# Altium schematic sheet pixracer_serials.SchDoc(pixracer_serials)
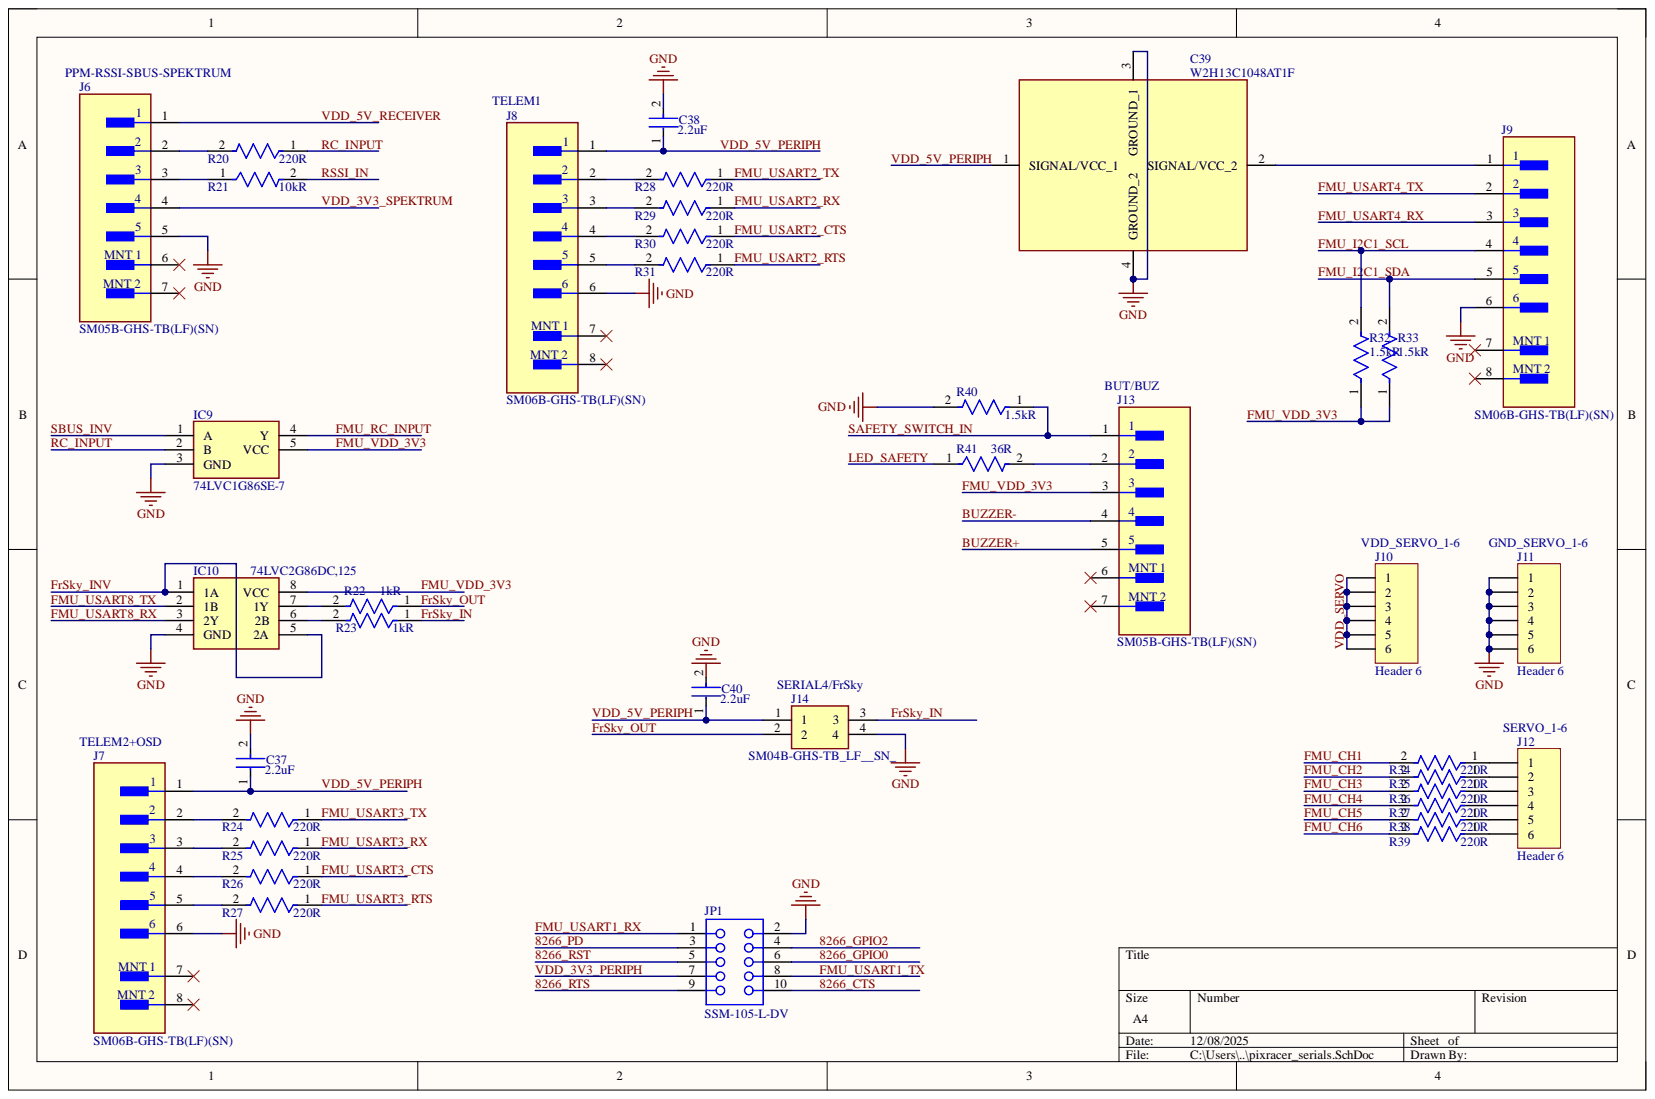
[diagram p0: pixracer_serials.SchDoc]

## Parts
C37  Capacitance: 2.2uF  [FP-C1608-080-0_1-MFG]  (hidden comment: C1608X7R1A225K080AC)
C38  Capacitance: 2.2uF  [FP-C1608-080-0_1-MFG]  (hidden comment: C1608X7R1A225K080AC)
C39  W2H13C1048AT1F  [W2H13C1048AT1A]
C40  Capacitance: 2.2uF  [FP-C1608-080-0_1-MFG]  (hidden comment: C1608X7R1A225K080AC)
IC9  74LVC1G86SE-7  [SOT65P210X110-5N]
IC10  74LVC2G86DC,125  [SOP50P310X100-8N]
J6  SM05B-GHS-TB(LF)(SN)  [FP-SM05B-GHS-TB_LF_SN-MFG]
J7  SM06B-GHS-TB(LF)(SN)  [FP-SM06B-GHS-TB_LF_SN-MFG]
J8  SM06B-GHS-TB(LF)(SN)  [FP-SM06B-GHS-TB_LF_SN-MFG]
J9  SM06B-GHS-TB(LF)(SN)  [FP-SM06B-GHS-TB_LF_SN-MFG]
J10  Header 6  [HDR1X6]
J11  Header 6  [HDR1X6]
J12  Header 6  [HDR1X6]
J13  SM05B-GHS-TB(LF)(SN)  [FP-SM05B-GHS-TB_LF_SN-MFG]
J14  SM04B-GHS-TB_LF__SN_  [SM04B-GHS-TB]
JP1  SSM-105-L-DV  [SMTC-SSM-105-X-DV]
R20  Resistance: 220R  [FP-CRCW0603-e3-MFG]  (hidden comment: CRCW0603220RFKEB)
R21  Resistance: 10kR  [FP-ERJ3G-IPC_C]  (hidden comment: ERJ-3GEYJ103V)
R22  Resistance: 1kR  [FP-RC1608-IPC_A]  (hidden comment: RC1608J102CS)
R23  Resistance: 1kR  [FP-RC1608-IPC_A]  (hidden comment: RC1608J102CS)
R24  Resistance: 220R  [FP-CRCW0603-e3-MFG]  (hidden comment: CRCW0603220RFKEB)
R25  Resistance: 220R  [FP-CRCW0603-e3-MFG]  (hidden comment: CRCW0603220RFKEB)
R26  Resistance: 220R  [FP-CRCW0603-e3-MFG]  (hidden comment: CRCW0603220RFKEB)
R27  Resistance: 220R  [FP-CRCW0603-e3-MFG]  (hidden comment: CRCW0603220RFKEB)
R28  Resistance: 220R  [FP-CRCW0603-e3-MFG]  (hidden comment: CRCW0603220RFKEB)
R29  Resistance: 220R  [FP-CRCW0603-e3-MFG]  (hidden comment: CRCW0603220RFKEB)
R30  Resistance: 220R  [FP-CRCW0603-e3-MFG]  (hidden comment: CRCW0603220RFKEB)
R31  Resistance: 220R  [FP-CRCW0603-e3-MFG]  (hidden comment: CRCW0603220RFKEB)
R32  value 1.5kR  [FP-CPF0603-MFG]  (hidden comment: CPF0603B1K5E1)
R33  value 1.5kR  [FP-CPF0603-MFG]  (hidden comment: CPF0603B1K5E1)
R34  Resistance: 220R  [FP-CRCW0603-e3-MFG]  (hidden comment: CRCW0603220RFKEB)
R35  Resistance: 220R  [FP-CRCW0603-e3-MFG]  (hidden comment: CRCW0603220RFKEB)
R36  Resistance: 220R  [FP-CRCW0603-e3-MFG]  (hidden comment: CRCW0603220RFKEB)
R37  Resistance: 220R  [FP-CRCW0603-e3-MFG]  (hidden comment: CRCW0603220RFKEB)
R38  Resistance: 220R  [FP-CRCW0603-e3-MFG]  (hidden comment: CRCW0603220RFKEB)
R39  Resistance: 220R  [FP-CRCW0603-e3-MFG]  (hidden comment: CRCW0603220RFKEB)
R40  Resistance: 1.5kR  [FP-MCR03-IPC_A]  (hidden comment: MCR03EZPFX1501)
R41  Resistance: 36R  [FP-CRCW0603-e3-IPC_B]  (hidden comment: CRCW060336R0JNEA)

## Nets
GND: C37.2 C38.2 C39.3(GROUND_1) C39.4(GROUND_2) C40.2 IC9.3(GND) IC10.4(GND) J6.5 J7.6 J8.6 J9.6 J11.1 J11.2 J11.3 J11.4 J11.5 J11.6 J14.4 JP1.2 R40.2
8266_CTS: JP1.10
8266_GPIO0: JP1.6
8266_GPIO2: JP1.4
8266_PD: JP1.3
8266_RST: JP1.5
8266_RTS: JP1.9
BUZZER+: J13.5
BUZZER-: J13.4
FMU_CH1: R34.2
FMU_CH2: R35.2
FMU_CH3: R36.2
FMU_CH4: R37.2
FMU_CH5: R38.2
FMU_CH6: R39.2
FMU_I2C1_SCL: J9.4 R32.2
FMU_I2C1_SDA: J9.5 R33.2
FMU_RC_INPUT: IC9.4(Y)
FMU_USART1_RX: JP1.1
FMU_USART1_TX: JP1.8
FMU_USART2_CTS: R30.1
FMU_USART2_RTS: R31.1
FMU_USART2_RX: R29.1
FMU_USART2_TX: R28.1
FMU_USART3_CTS: R26.1
FMU_USART3_RTS: R27.1
FMU_USART3_RX: R25.1
FMU_USART3_TX: R24.1
FMU_USART4_RX: J9.3
FMU_USART4_TX: J9.2
FMU_USART8_RX: IC10.3(2Y)
FMU_USART8_TX: IC10.2(1B)
FMU_VDD_3V3: IC9.5(VCC) IC10.8(VCC) J13.3 R32.1 R33.1
FrSky_IN: J14.3 R23.1
FrSky_INV: IC10.1(1A) IC10.5(2A)
FrSky_OUT: J14.2 R22.1
LED_SAFETY: R41.1
RC_INPUT: IC9.2(B) R20.1
RSSI_IN: R21.2
SAFETY_SWITCH_IN: J13.1 R40.1
SBUS_INV: IC9.1(A)
VDD_3V3_PERIPH: JP1.7
VDD_3V3_SPEKTRUM: J6.4
VDD_5V_PERIPH: C37.1 C38.1 C39.1(SIGNAL/VCC_1) C40.1 J7.1 J8.1 J14.1
VDD_5V_RECEIVER: J6.1
VDD_SERVO: J10.1 J10.2 J10.3 J10.4 J10.5 J10.6
NetC39_2: C39.2(SIGNAL/VCC_2) J9.1
NetIC10_6: IC10.6(2B) R23.2
NetIC10_7: IC10.7(1Y) R22.2
NetJ6_2: J6.2 R20.2
NetJ6_3: J6.3 R21.1
NetJ7_2: J7.2 R24.2
NetJ7_3: J7.3 R25.2
NetJ7_4: J7.4 R26.2
NetJ7_5: J7.5 R27.2
NetJ8_2: J8.2 R28.2
NetJ8_3: J8.3 R29.2
NetJ8_4: J8.4 R30.2
NetJ8_5: J8.5 R31.2
NetJ12_1: J12.1 R34.1
NetJ12_2: J12.2 R35.1
NetJ12_3: J12.3 R36.1
NetJ12_4: J12.4 R37.1
NetJ12_5: J12.5 R38.1
NetJ12_6: J12.6 R39.1
NetJ13_2: J13.2 R41.2

Not connected: J6 6 7; J7 7 8; J8 7 8; J9 7 8; J13 6 7

## Library data of the parts
C37 C38 C40 = CMP-08246-006835-1: Multilayer Ceramic Capacitors 2.2μF ±10% 10V X7R SMD 0603; Case Code (Imperial): 0603; Case Code (Metric): 1608; Case/Package: 0603; Component Kind: Standard; Construction: Flat; Depth: 0.8mm; Dielectric: X7R; Dielectric Material: Ceramic; Height: 0.8mm; Lead Free: Lead Free; Length: 1.6mm; Library Name: Altium Content Vault; Max Operating Temperature: 125°C; Min Operating Temperature: -55°C; Mount: Surface Mount; Package Quantity: 4000; Packaging: Tape and Reel; REACH SVHC: No SVHC; Resistor Type: Ceramic; RoHS Compliant: Yes; Series: C; Termination: SMD/SMT; Thickness: 0.037inch; Tolerance: 10%; Voltage Rating: 10V; Voltage Rating (DC): 10V; Weight: 7.1E-05oz; Width: 0.031inch
C39 = W2H13C1048AT1F: Capacitor; Manufacturer_Name: AVX; Arrow Part Number: W2H13C1048AT1F; Arrow Price/Stock: https://www.arrow.com/en/products/w2h13c1048at1f/avx; Component Kind: Standard; Datasheet Link: https://componentsearchengine.com/Datasheets/1/W2H13C1048AT1F.pdf; Height: 1mm; Manufacturer_Part_Number: W2H13C1048AT1F; Mouser Part Number: 581-W2H13C1048AT1F; Mouser Price/Stock: https://www.mouser.com/Search/Refine.aspx?Keyword=581-W2H13C1048AT1F
IC9 = 74LVC1G86SE-7: Integrated Circuit; Manufacturer_Name: Diodes Inc.; Arrow Part Number: 74LVC1G86SE-7; Arrow Price/Stock: https://www.arrow.com/en/products/74lvc1g86se-7/diodes-incorporated?region=nac; Component Kind: Standard; Datasheet Link: https://www.diodes.com/assets/Datasheets/74LVC1G86.pdf; Height: 1.1mm; Manufacturer_Part_Number: 74LVC1G86SE-7; Mouser Part Number: 621-74LVC1G86SE-7; Mouser Price/Stock: https://www.mouser.co.uk/ProductDetail/Diodes-Incorporated/74LVC1G86SE-7/?qs=8Dv1RiiPiVJ%252BnYSWg3kTgg%3D%3D
IC10 = 74LVC2G86DC,125: Integrated Circuit; Manufacturer_Name: Nexperia; Arrow Part Number: 74LVC2G86DC,125; Arrow Price/Stock: https://www.arrow.com/en/products/74lvc2g86dc125/nexperia?region=nac; Component Kind: Standard; Datasheet Link: https://assets.nexperia.com/documents/data-sheet/74LVC2G86.pdf; Height: 1mm; Manufacturer_Part_Number: 74LVC2G86DC,125; Mouser Part Number: 771-LVC2G86DC125; Mouser Price/Stock: https://www.mouser.co.uk/ProductDetail/Nexperia/74LVC2G86DC125?qs=me8TqzrmIYXbZy3sMRjHUw%3D%3D
J6 J13 = CMP-17439-000048-1: CONN HEADER SMD R/A 5POS 1.25MM; Connector Type: Outer Shroud Contact; Current Rating: 1A; Number of Contacts: 5; Pitch: 0.049inch; Voltage Rating: 50V; Wire/Cable Gauge: 26AWG; Color: Natural; Component Kind: Standard; Contact Material: Bronze; Contact Plating: Tin; Contact Type: Outer Shroud Contact; Fastening Type: Ramp; Housing Color: Natural; Housing Material: Nylon; Lead Free: Lead Free; Library Name: Altium Content Vault; Max Operating Temperature: 85°C; Min Operating Temperature: -25°C; Mount: Surface Mount; Number of Positions: 5; Number of Rows: 1; Orientation: Right Angle; Packaging: Tape and Reel; REACH SVHC: No SVHC; Series: GH; Termination: Solder; Voltage Rating (AC): 50V
J7-J9 = CMP-17439-000061-1: CONN HEADER SMD R/A 6POS 1.25MM; Connector Type: Outer Shroud Contact; Contact Resistance: 50mR; Current Rating: 1A; Max Voltage Rating (AC): 50V; Number of Contacts: 6; Color: Natural; Component Kind: Standard; Contact Material: Bronze; Contact Plating: Tin; Depth: 4.05mm; Fastening Type: Ramp; Housing Color: Beige; Housing Material: Polyamide; Lead Free: Lead Free; Length: 10.75mm; Library Name: Altium Content Vault; Max Current Rating: 1A; Max Operating Temperature: 85°C; Min Operating Temperature: -25°C; Mount: Surface Mount; Number of Positions: 6; Number of Rows: 1; Orientation: Right Angle; Packaging: Tape and Reel; Pitch: 1.25mm; Termination: Solder; Voltage Rating: 50V
J10-J12 = Header 6: Header, 6-Pin; Component Kind: Standard; LatestRevisionDate: 17-Jul-2002; LatestRevisionNote: Re-released for DXP Platform.; Library Name: Miscellaneous Connectors.IntLib; Signal Integrity: Connector; Publisher: Altium Limited
J14 = SM04B-GHS-TB_LF__SN_: Connector; Manufacturer_Name: JST (JAPAN SOLDERLESS TERMINALS); Manufacturer_Part_Number: SM04B-GHS-TB(LF)(SN); Component Kind: Standard; Datasheet Link: http://uk.rs-online.com/web/p/products/7521797
JP1 = CMP-1023-00207-1: Tiger Claw(TM) Surface Mount Socket, Vertical, -55 to 125 degC, 2.54 mm Pitch, 10-Pin, Female, RoHS; Manufacturer: Samtec; Pitch: 2.54 mm; Component Kind: Standard; ComponentLink1Description: Manufacturer URL; ComponentLink1URL: http://www.samtec.com; ComponentLink2Description: Datasheet; ComponentLink2URL: http://www.samtec.com/documents/webfiles/pdf/SSM_SM.PDF; ComponentLink3Description: Package Specification; ComponentLink3URL: http://www.samtec.com/documents/webfiles/cpdf/SSM-1XX-XXX-DV-XX-XX-X-XX-MKT.pdf; DatasheetVersion: F-214; Library Name: Altium Content Vault; Mounting Technology: Surface Mount; Orientation: Vertical; PackageDescription: .100 C.L. Double Vertical SMT Socket Strip; PackageReference: SSM-105-X-DV; PackageVersion: AK, 3/2000; PartNumber: SSM-105-L-DV; RoHS: True; Temperature Range: -55 to 125 degC
R20 R24-R31 R34-R39 = CMP-02407-003555-1: RES Thick Film, 220Ω, 1%, 0.1W, 100ppm/°C, 0603; Max Power Dissipation: 100mW; Voltage Rating: 75V; Case Code (Imperial): 0603; Case Code (Metric): 1608; Case/Package: 0603; Component Kind: Standard; Composition: Thick Film; Depth: 0.85mm; Features: Automotive AEC-Q200; Height: 0.02inch; Lead Free: Lead Free; Length: 1.55mm; Library Name: Altium Content Vault; Max Operating Temperature: 155°C; Military Standard: Not; Min Operating Temperature: -55°C; Number of Terminations: 2; Package Quantity: 10000; Packaging: Tape and Reel; Power Rating: 100mW; Temperature Coefficient: 100ppm/°C; Termination: SMD/SMT; Tolerance: 1%; Weight: 7.1E-05oz; Width: 0.85mm
R21 = CMP-2000-00704-2: RES SMD 10K OHM 5% 1/10W 0603; Max Power Dissipation: 100mW; Temperature Coefficient: 200ppm/°C; Voltage Rating: 75V; Voltage Rating (DC): 50V; Case Code (Imperial): 0603; Case Code (Metric): 1608; Case/Package: 0603; Component Kind: Standard; Construction: Rectangular; Contact Plating: Tin; Depth: 0.8mm; Features: Automotive AEC-Q200; Height: 0.45mm; Lead Free: Lead Free; Length: 1.6mm; Library Name: Altium Content Vault; Max Operating Temperature: 155°C; Military Standard: Not; Min Operating Temperature: -55°C; Mount: Surface Mount; Number of Terminations: 2; Package Quantity: 1; Packaging: Tape and Reel; Power Rating: 100mW; REACH SVHC: No SVHC; Resistance Tolerance: 5%; Resistor Type: Thick Film; RoHS Compliant: Yes; Series: ERJ; Technology: THICK FILM; Termination: SMD/SMT; Tolerance: 5%; Weight: 7.1E-05oz; Width: 0.8mm; Working Voltage: 75V
R22 R23 = CMP-13261-002301-1: Res Thick Film 0603 1kΩ 5% 0.1W ±100ppm/°C Molded SMD T/R; Max Power Dissipation: 100mW; Voltage Rating (DC): 50V; Case Code (Imperial): 0603; Case Code (Metric): 1608; Case/Package: 0603; Component Kind: Standard; Composition: Thick Film; Contact Plating: Tin; Depth: 0.8mm; Features: Moisture Resistant; Height: 0.022inch; Lead Free: Lead Free; Length: 1.6mm; Library Name: Altium Content Vault; Max Operating Temperature: 155°C; Military Standard: Not; Min Operating Temperature: -55°C; Mount: Surface Mount; Number of Terminations: 2; Packaging: Tape and Reel; Power Rating: 100mW; Radiation Hardening: No; Temperature Coefficient: 100ppm/°C; Tolerance: 5%; Width: 0.031inch
R32 R33 = CMP-03211-005433-1: RES SMD 1.5KΩ 0.1% 1/16W 0603; Manufacturer: TE Connectivity; Power Rating: 0.063W; Temperature Coefficient: 25ppm/°C; Voltage Rating: 50V; Automotive: No; Category: Res; Component Kind: Standard; ComponentLink2Description: Datasheet; ComponentLink2URL: https://www.te.com/commerce/DocumentDelivery/DDEController?Action=showdoc&DocId=Data+Sheet%7F1773200%7FM%7Fpdf%7FEnglish%7FENG_DS_1773200_M.pdf%7F3-1676481-2; Composition: Thin Film; Device Class L1: Passive Components; Device Class L2: Resistors; Device Class L3: Chip SMD Resistors; Height: 0.55mm; Ipc Land Pattern Name: RESC15580X45; Lead Free: Yes; Library Name: Altium Content Vault; Manufacturer Part Number: CPF0603B1K5E1; Octopart: https://octopart.com/cpf0603b1k5e1-te+connectivity-9539421; octopart_url: https://octopart.com/cpf0603b1k5e1-te+connectivity-9539421; Package: CPF0603; packaging: 1k Reel; Resistance: 1.5KΩ; RoHS: TRUE; Series: CPF; Temperature: -55°C to +155°C; Temperature Range High: +155°C; Temperature Range Low: -55°C; Tolerance: 0.1%
R40 = CMP-08839-007837-1: Thick Film Chip Resistor, 0603, 1.5kΩ, 1%, 100ppm/°C, 0.1W, 50V; Max Power Dissipation: 100mW; Case Code (Imperial): 0603; Case Code (Metric): 1608; Case/Package: 0603; Component Kind: Standard; Depth: 0.8mm; Features: Automotive AEC-Q200; Height: 0.022inch; Lead Free: Lead Free; Length: 0.063inch; Library Name: Altium Content Vault; Max Operating Temperature: 155°C; Military Standard: Not; Min Operating Temperature: -55°C; Mount: Surface Mount; Number of Terminations: 2; Package Quantity: 5000; Packaging: Tape and Reel; Power Rating: 100mW; Resistor Type: Thick Film; RoHS Compliant: Yes; Temperature Coefficient: 100ppm/°C; Tolerance: 1%; Voltage Rating (DC): 50V; Width: 0.031inch
R41 = CMP-2000-03274-2: RES Thick Film, 36Ω, 5%, 0.1W, 200ppm/°C, 0603; Max Power Dissipation: 100mW; Voltage Rating: 75V; Case Code (Imperial): 0603; Case Code (Metric): 1608; Case/Package: 0603; Component Kind: Standard; Composition: Thick Film; Contact Plating: Tin; Features: Automotive AEC-Q200; Height: 0.02inch; Lead Free: Lead Free; Length: 0.061inch; Library Name: Altium Content Vault; Max Operating Temperature: 155°C; Min Operating Temperature: -55°C; Number of Terminations: 2; Package Quantity: 1; Packaging: Tape and Reel; Power Rating: 100mW; Radiation Hardening: No; Temperature Coefficient: 200ppm/°C; Tolerance: 5%; Voltage Rating (DC): 75V; Weight: 7.1E-05oz; Width: 0.033inch
